# Altium schematic sheet ESP32-C5.SchDoc(ESP32-C5)
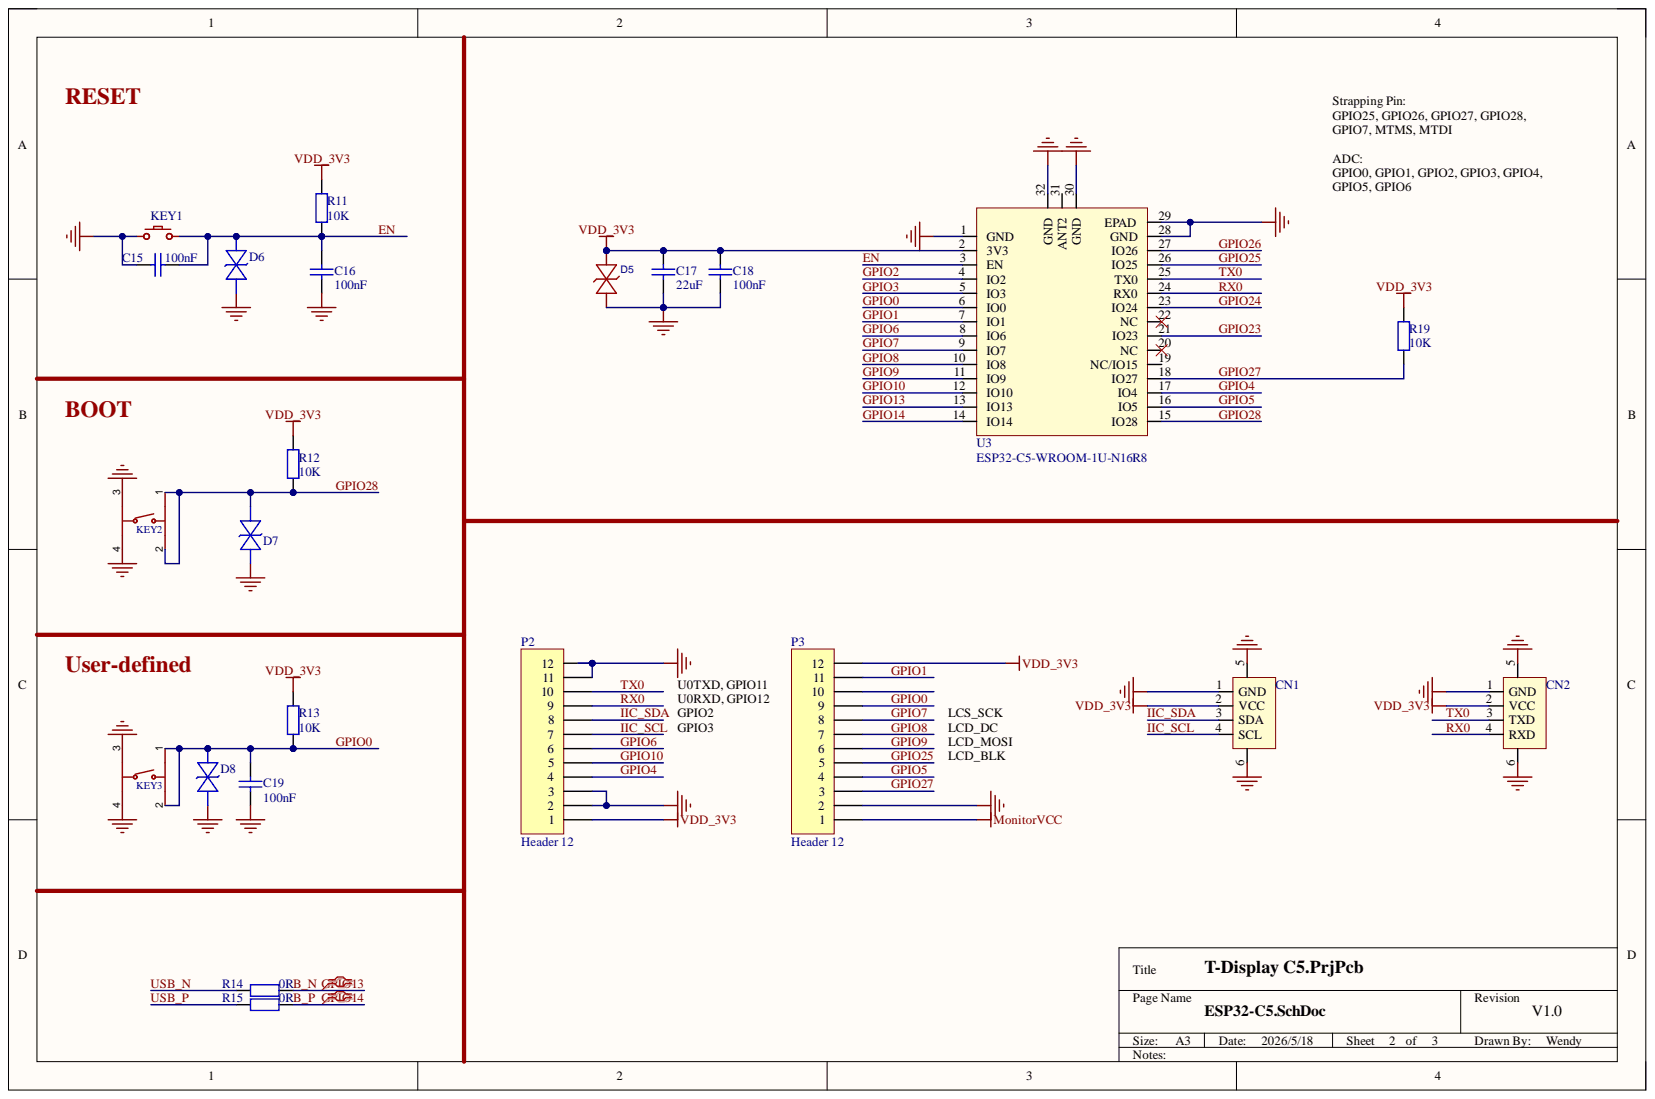
[diagram p0: ESP32-C5.SchDoc]

## Parts
C15  100nF  [C0402]
C16  100nF  [C0402]
C17  22uF  [C0603]
C18  100nF  [C0402]
C19  100nF  [C0402]
CN1  ZX-SH1.0-4PWT  [CONN-SMD_4P-P1.00_MEGASTAR_ZX-SH1.0-4PWT]  (value not drawn)
CN2  ZX-SH1.0-4PWT  [CONN-SMD_4P-P1.00_MEGASTAR_ZX-SH1.0-4PWT]  (value not drawn)
D5  GBLC05C_C502539  [SOD-323_L1.8-W1.3-LS2.5-BI]  (value not drawn)
D6  ESD05V88D-LC  [DFN-SMD_L1.0-W0.6-BI]  (value not drawn)
D7  ESD05V88D-LC  [DFN-SMD_L1.0-W0.6-BI]  (value not drawn)
D8  ESD05V88D-LC  [DFN-SMD_L1.0-W0.6-BI]  (value not drawn)
KEY1  TS24CA  [KEY_EDGE]  (value not drawn)
KEY2  TS-1089S-02526  [SW-SMD_4P-L4.2-W3.3-P2.15-LS5.1]  (value not drawn)
KEY3  TS-1089S-02526  [SW-SMD_4P-L4.2-W3.3-P2.15-LS5.1]  (value not drawn)
P2  Header 12  [HDR1X12]
P3  Header 12  [HDR1X12]
R11  10K  [R0402]
R12  10K  [R0402]
R13  10K  [R0402]
R14  0R  [R0402]
R15  0R  [R0402]
R19  10K  [R0402]
U3  ESP32-C5-WROOM-1U-N16R8  [COMM-SMD_32P-L21.2-W18.0_ESP32-C5-WROOM-1U]

## Nets
GND: C15.1 C16.1 C17.2 C18.2 C19.1 CN1.1(GND) CN1.5 CN1.6 CN2.1(GND) CN2.5 CN2.6 D5.1 D6.2 D7.2 D8.2 KEY1.2 KEY1.3 KEY1.4 KEY2.3 KEY2.4 KEY3.3 KEY3.4 P2.2 P2.3 P2.11 P2.12 P3.2 U3.1(GND) U3.28(GND) U3.29(EPAD) U3.30(GND) U3.32(GND)
MonitorVCC: P3.1
VDD_3V3: C17.1 C18.1 CN1.2(VCC) CN2.2(VCC) D5.2 P2.1 P3.12 R11.2 R12.2 R13.2 R19.2 U3.2(3V3)
B_N: R14.1 U3.13(IO13)
B_P: R15.1 U3.14(IO14)
EN: C15.2 C16.2 D6.1 KEY1.1 R11.1 U3.3(EN)
GPIO0: C19.2 D8.1 KEY3.1 KEY3.2 P3.9 R13.1 U3.6(IO0)
GPIO1: P3.11 U3.7(IO1)
GPIO2: U3.4(IO2)
GPIO3: U3.5(IO3)
GPIO4: P2.4 U3.17(IO4)
GPIO5: P3.4 U3.16(IO5)
GPIO6: P2.6 U3.8(IO6)
GPIO7: P3.8 U3.9(IO7)
GPIO8: P3.7 U3.10(IO8)
GPIO9: P3.6 U3.11(IO9)
GPIO10: P2.5 U3.12(IO10)
GPIO23: U3.21(IO23)
GPIO24: U3.23(IO24)
GPIO25: P3.5 U3.26(IO25)
GPIO26: U3.27(IO26)
GPIO27: P3.3 R19.1 U3.18(IO27)
GPIO28: D7.1 KEY2.1 KEY2.2 R12.1 U3.15(IO28)
IIC_SCL: CN1.4(SCL) P2.7
IIC_SDA: CN1.3(SDA) P2.8
RX0: CN2.4(RXD) P2.9 U3.24(RX0)
TX0: CN2.3(TXD) P2.10 U3.25(TX0)
USB_N: R14.2
USB_P: R15.2

Not connected: P3 10; U3 19(NC/IO15) 20(NC) 22(NC) 31(ANT2)

## Library data of the parts
C15-C19 = Cap: Capacitor; Component Kind: Standard; LatestRevisionDate: 17-Jul-2002; LatestRevisionNote: Re-released for DXP Platform.; Library Name: Miscellaneous Devices.IntLib; PackageDescription: Radial Cap, Thru-Hole; 2 Leads; 0.3 in Pin Spacing; PackageReference: RAD-0.3; Published: 8-Jun-2000; Signal Integrity: Cap; Simulation: CAP; Publisher: Altium Limited
  C15 C16 C18 C19: Value: 100nF
  C17: Value: 22uF
CN1 = 1.0mm 1x4Pin: Component Kind: Standard; Library Name: WendyLib.SchLib
CN2 = 1.0mm 1x4Pin_1: Component Kind: Standard; Library Name: WendyLib.SchLib
D6-D8 = ESD05V88D-LC: Symbol: ESD05V88D-LC_C5139330; Component Kind: Standard; Library Name: Wendy Wen.SchLib
KEY1 = C_SW_KEY_1: Component Kind: Standard; Library Name: T-DISPLAY-S3-1.91-V1.0.SCHLIB
P2 P3 = Header 12: Header, 12-Pin; Component Kind: Standard; LatestRevisionDate: 17-Jul-2002; LatestRevisionNote: Re-released for DXP Platform.; Library Name: Miscellaneous Connectors.IntLib; Signal Integrity: Connector; Publisher: Altium Limited
R11-R15 R19 = Res2: Resistor; Component Kind: Standard; LatestRevisionDate: 17-Jul-2002; LatestRevisionNote: Re-released for DXP Platform.; Library Name: Miscellaneous Devices.IntLib; PackageDescription: Axial Device, Thru-Hole; 2 Leads; 0.4 in Pin Spacing; PackageReference: AXIAL-0.4; Published: 8-Jun-2000; Signal Integrity: Res; Simulation: RESISTOR; Publisher: Altium Limited
  R11-R13 R19: Value: 10K
  R14 R15: Value: 0R
U3 = ESP32-C5-WROOM-1U-N16R8: Symbol: ESP32-C5-WROOM-1U-N8-R4; Component Kind: Standard; Library Name: WendyLib.SchLib
D5 = GBLC05C_C502539: Component Kind: Standard; Symbol: GBLC05C_C502539
KEY2 KEY3 = TS-1089S-02526: Component Kind: Standard; Symbol: TS-1089S-02526; Library Name: Wendy Wen.SchLib
